# Altium schematic sheet CNTR.SchDoc(CNTR)
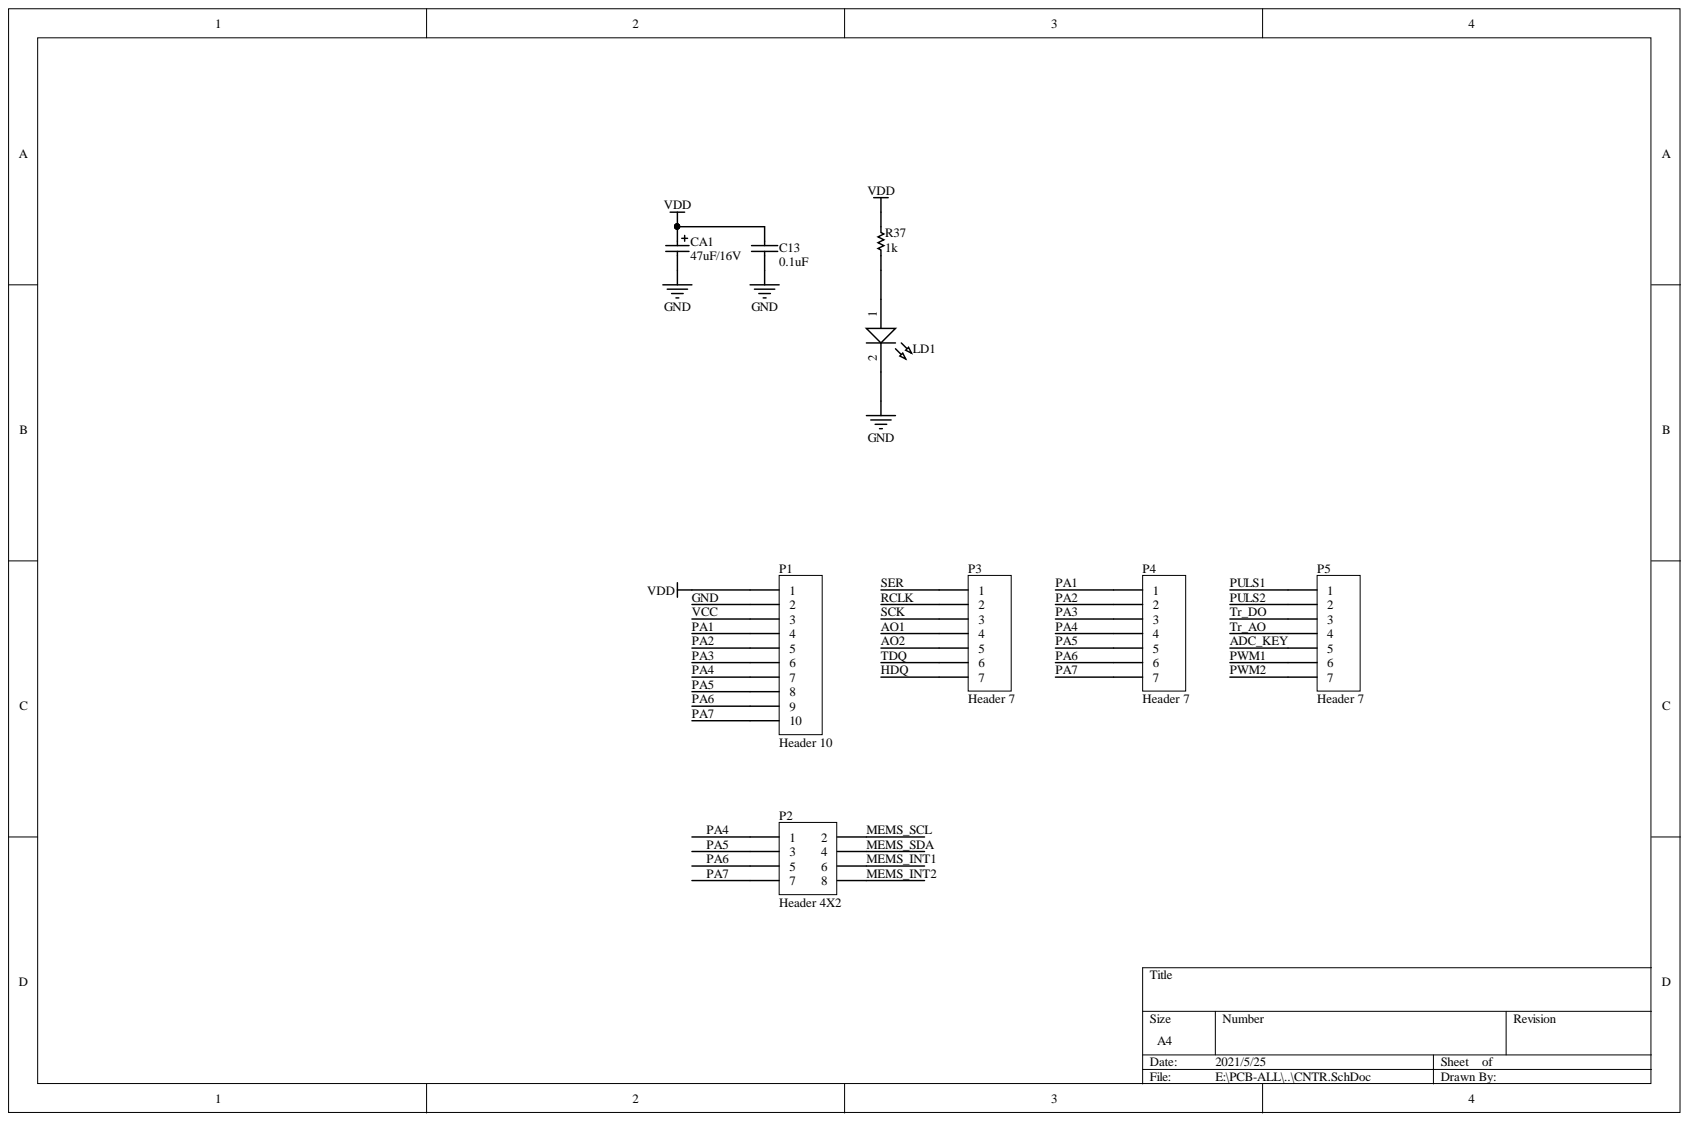
[diagram p0: CNTR.SchDoc]

## Parts
C13  0.1uF  [C0805]
CA1  47uF/16V  [CAP-5X7]
LD1  Red  [LED-0805]  (value not drawn)
P1  Header 10  [HDR1X10]
P2  Header 4X2  [HDR2X4]
P3  Header 7  [HDR1X7]
P4  Header 7  [HDR1X7]
P5  Header 7  [HDR1X7]
R37  1k  [R0805]

## Nets
VDD: C13.1 CA1.1 P1.1 R37.2
ADC_KEY: P5.5
AO1: P3.4
AO2: P3.5
GND: C13.2 CA1.2 LD1.2 P1.2
HDQ: P3.7
MEMS_INT1: P2.6
MEMS_INT2: P2.8
MEMS_SCL: P2.2
MEMS_SDA: P2.4
PA1: P1.4 P4.1
PA2: P1.5 P4.2
PA3: P1.6 P4.3
PA4: P1.7 P2.1 P4.4
PA5: P1.8 P2.3 P4.5
PA6: P1.9 P2.5 P4.6
PA7: P1.10 P2.7 P4.7
PULS1: P5.1
PULS2: P5.2
PWM1: P5.6
PWM2: P5.7
RCLK: P3.2
SCK: P3.3
SER: P3.1
TDQ: P3.6
TR_AO: P5.4
TR_DO: P5.3
VCC: P1.3
NetLD1_1: LD1.1 R37.1

## Library data of the parts
C13 = CAP: Capacitor; 中文名称: 贴片电容; Component Kind: Standard; Library Name: 2014.SchLib
CA1 = Cap Pol1: Polarized Capacitor (Radial); Value: 47uF; 中文名称: 钽电容; Component Kind: Standard; Library Name: 2014.SchLib; Published: 8-Jun-2000; Revision: July-2002: Re-released for DXP Platform.; Signal Integrity: C310M; Simulation: CAP; Publisher: Altium Limited
LD1 = LED2: Typical RED, GREEN, YELLOW, AMBER GaAs LED; Component Kind: Standard; Library Name: 2014.SchLib; Published: 8-Jun-2000; Revision: July-2002: Re-released for DXP Platform.; Publisher: Altium Limited
P1 = Header 10: Header, 10-Pin; Component Kind: Standard; LatestRevisionDate: 17-Jul-2002; LatestRevisionNote: Re-released for DXP Platform.; Library Name: Miscellaneous Connectors.IntLib; Signal Integrity: Connector; Publisher: Altium Limited
P2 = Header 4X2: Header, 4-Pin, Dual row; Component Kind: Standard; LatestRevisionDate: 17-Jul-2002; LatestRevisionNote: Re-released for DXP Platform.; Library Name: Miscellaneous Connectors.IntLib; Signal Integrity: Connector; Publisher: Altium Limited
P3-P5 = Header 7: Header, 7-Pin; Component Kind: Standard; LatestRevisionDate: 17-Jul-2002; LatestRevisionNote: Re-released for DXP Platform.; Library Name: Miscellaneous Connectors.IntLib; Signal Integrity: Connector; Publisher: Altium Limited
R37 = Res1: 电阻; Component Kind: Standard; Library Name: 2014.SchLib; Published: 8-Jun-2000; Revision: July-2002: Re-released for DXP Platform.; Signal Integrity: Res; Simulation: RESISTOR; Value: 1K; Publisher: Altium Limited
